# Altium schematic sheet stm32H.SchDoc
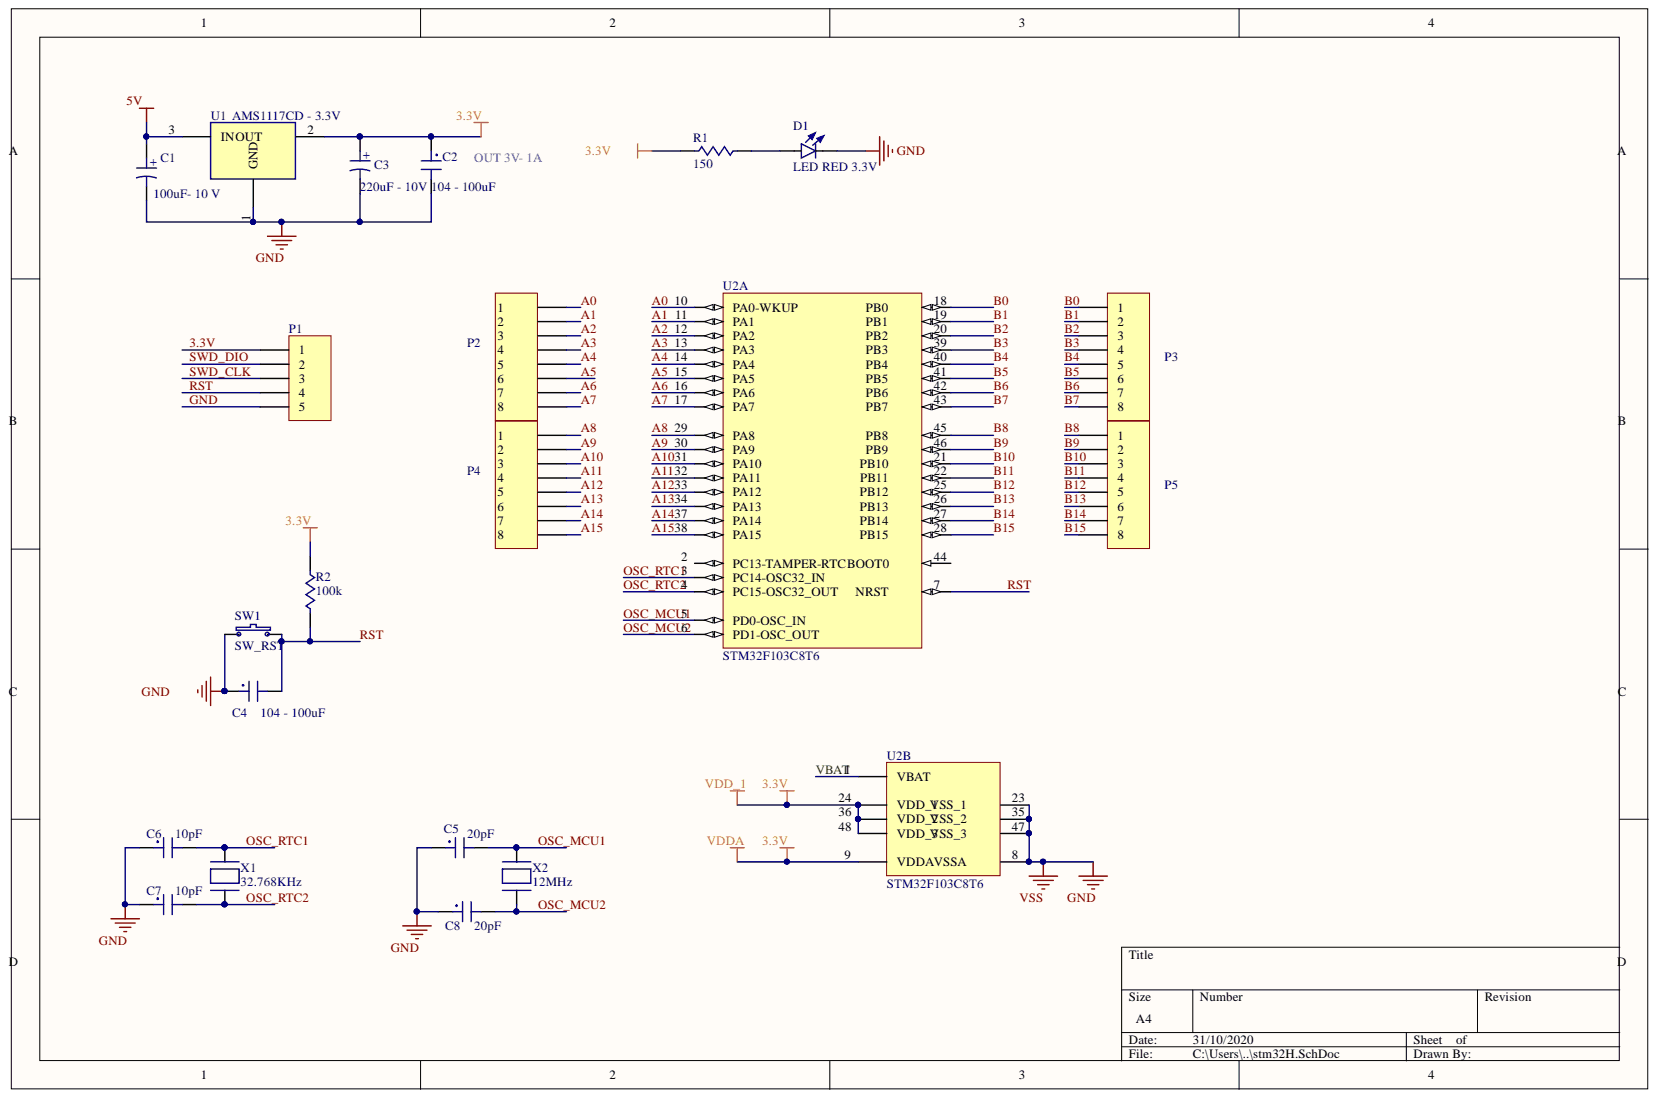
[diagram p0: stm32H.SchDoc]

## Parts
C1  100uF- 10 V  [CAP_T_6032–25_CASE-C]
C2  104 - 100uF  value 100uF  [RES0805]
C3  220uF - 10V  [CAP_T_7343–43_CASE-X]
C4  104 - 100uF  value 100uF  [RES0805]
C5  20pF  [RES0805]
C6  10pF  [RES0805]
C7  10pF  [RES0805]
C8  20pF  [RES0805]
D1  LED RED 3.3V  [LED_SMD_0805]
P1  Header 5  [HDR1X5]  (value not drawn)
P2  Header 8  [HDR1X8]  (value not drawn)
P3  Header 8  [HDR1X8]  (value not drawn)
P4  Header 8  [HDR1X8]  (value not drawn)
P5  Header 8  [HDR1X8]  (value not drawn)
R1  150  [RES0805]
R2  100k  [RES0805]
SW1  SW_RST  [SW_2pin_SMD]
U1  AMS1117CD - 3.3V  [SOT_223]
U2  STM32F103C8T6  [LQFP48_L]  (parts A,B)
X1  32.768KHz  [OSC_3x8mm]
X2  12MHz  [OSC_2pin 200ml]

## Nets
5V: C1.1 U1.3
3.3V: C2.1 C3.1 P1.1 R1.2 R2.2 U1.2 U2.9 U2.24(VDD_1) U2.36(VDD_2) U2.48(VDD_3)
A0: P2.1 U2.10(PA0-WKUP)
A1: P2.2 U2.11(PA1)
A2: P2.3 U2.12(PA2)
A3: P2.4 U2.13(PA3)
A4: P2.5 U2.14(PA4)
A5: P2.6 U2.15(PA5)
A6: P2.7 U2.16(PA6)
A7: P2.8 U2.17(PA7)
A8: P4.1 U2.29(PA8)
A9: P4.2 U2.30(PA9)
A10: P4.3 U2.31(PA10)
A11: P4.4 U2.32(PA11)
A12: P4.5 U2.33(PA12)
A13: P4.6 U2.34(PA13)
A14: P4.7 U2.37(PA14)
A15: P4.8 U2.38(PA15)
B0: P3.1 U2.18
B1: P3.2 U2.19
B2: P3.3 U2.20
B3: P3.4 U2.39
B4: P3.5 U2.40
B5: P3.6 U2.41
B6: P3.7 U2.42
B7: P3.8 U2.43
B8: P5.1 U2.45
B9: P5.2 U2.46
B10: P5.3 U2.21
B11: P5.4 U2.22
B12: P5.5 U2.25
B13: P5.6 U2.26
B14: P5.7 U2.27
B15: P5.8 U2.28
GND: C1.2 C2.2 C3.2 C4.1 C5.1 C6.1 C7.1 C8.1 D1.2 P1.5 SW1.1 U1.1 U2.8 U2.23 U2.35 U2.47
OSC_MCU1: C5.2 U2.5(PD0-OSC_IN) X2.2
OSC_MCU2: C8.2 U2.6(PD1-OSC_OUT) X2.1
OSC_RTC1: C6.2 U2.3(PC14-OSC32_IN) X1.2
OSC_RTC2: C7.2 U2.4(PC15-OSC32_OUT) X1.1
RST: C4.2 P1.4 R2.1 SW1.2 U2.7
SWD_CLK: P1.3
SWD_DIO: P1.2
VBAT: U2.1(VBAT)
NetD1_1: D1.1 R1.1

Not connected: U2 2 44

## Library data of the parts
C1 C3 = CAP_E: Component Kind: Standard; Library Name: tiennguyen.SchLib
C2 C4 = CAP: Component Kind: Standard; Library Name: tiennguyen.SchLib
C5-C8 = CAP: VALUE: 100uF; Component Kind: Standard; Library Name: tiennguyen.SchLib
D1 = LED: Component Kind: Standard; Library Name: tiennguyen.SchLib
P1 = Header 5: Header, 5-Pin; Component Kind: Standard; LatestRevisionDate: 17-Jul-2002; LatestRevisionNote: Re-released for DXP Platform.; Library Name: CONECTER.SchLib; Publisher: Altium Limited
P2-P5 = Header 8: Header, 8-Pin; Component Kind: Standard; LatestRevisionDate: 17-Jul-2002; LatestRevisionNote: Re-released for DXP Platform.; Signal Integrity: Connector; Library Name: tiennguyen.IntLib; Publisher: Altium Limited
R1 R2 = RES: Component Kind: Standard; Library Name: tiennguyen.SchLib
SW1 = SW_2PIN: Component Kind: Standard; Library Name: tiennguyen.SchLib
U1 = AMS1117: AMS1117 IN<12V; value: AMS1117CD-5.0 V; value: AMS1117CD-2.85V; value: AMS1117CD-2.5V; value: AMS1117CD-1.8V; value: AMS1117CD-1.5V; Component Kind: Standard; value: AMS1117CD-3.3V; Library Name: tiennguyen.SchLib
U2 = STM32F103C8T6: ARM Cortex-M3 32-bit MCU, 64 KB Flash, 20 KB Internal RAM, 37 I/Os, 48-pin LQFP, -40 to 85 degC, Tray; Component Kind: Standard; Library Name: tiennguyen.SchLib
X1 X2 = OSC: X 12MHz; Component Kind: Standard; Library Name: tiennguyen.SchLib
